# Altium schematic sheet 3 - Sensors, LoRa.SchDoc
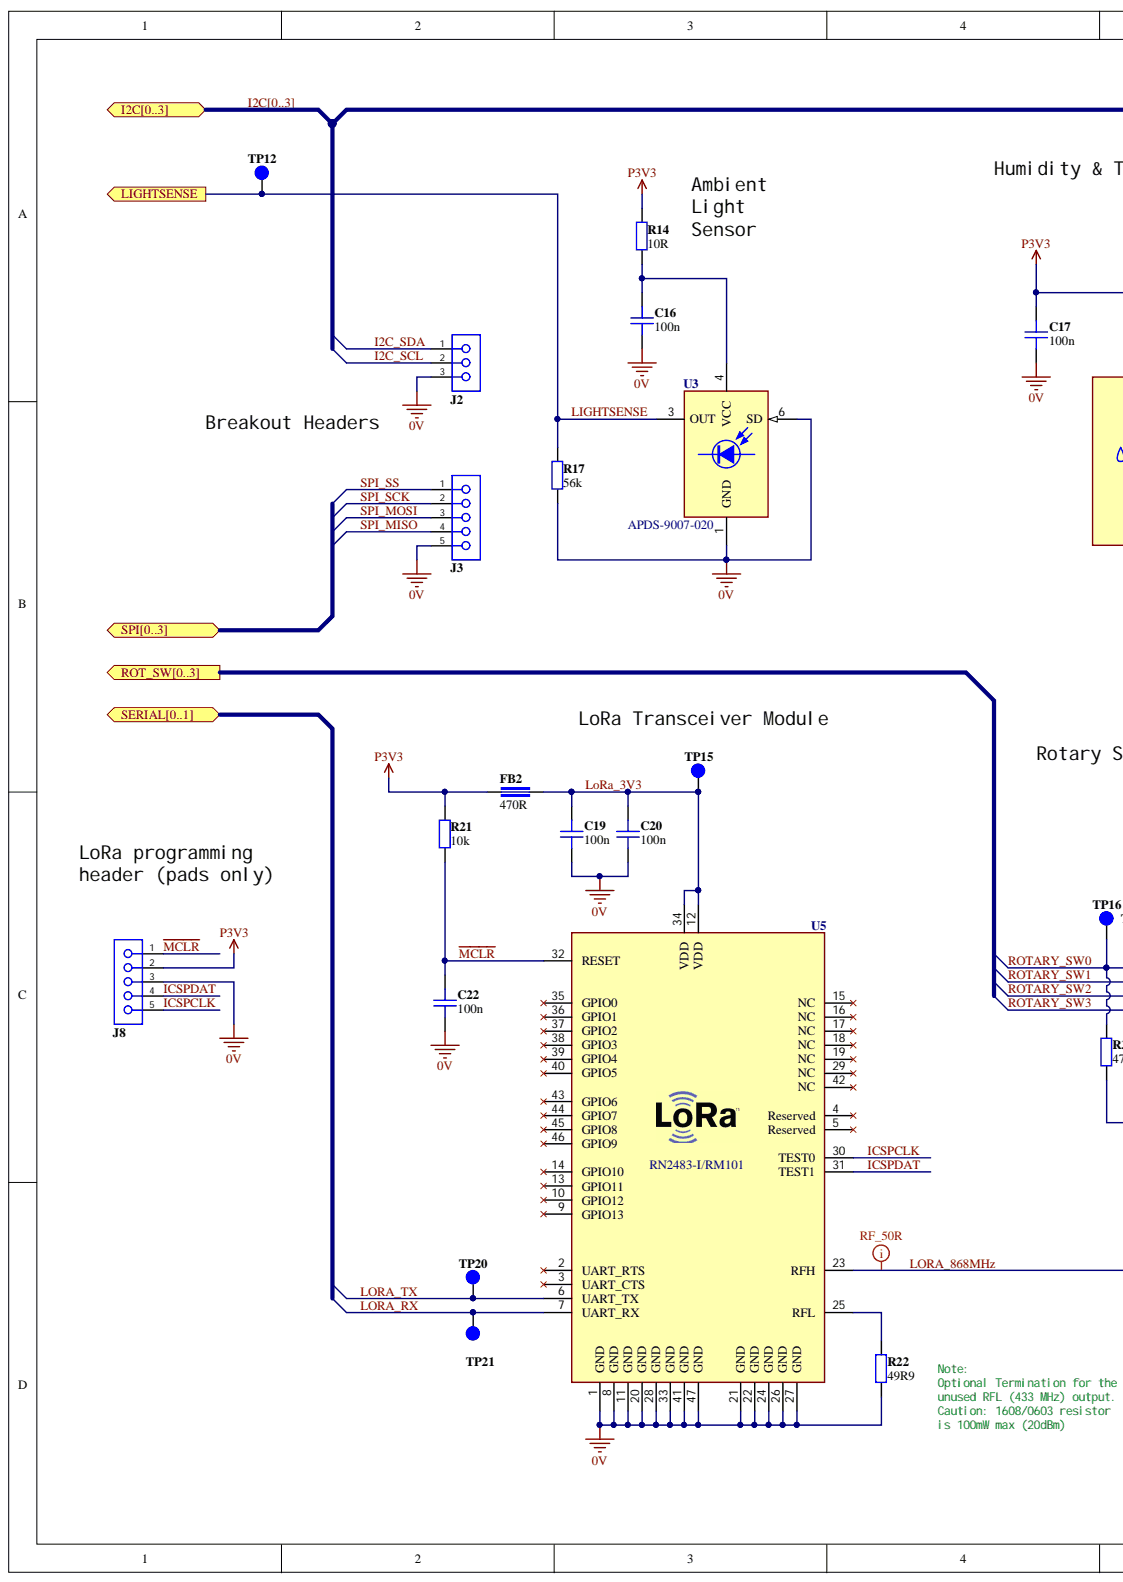
[diagram p0: 3 - Sensors, LoRa.SchDoc, left]
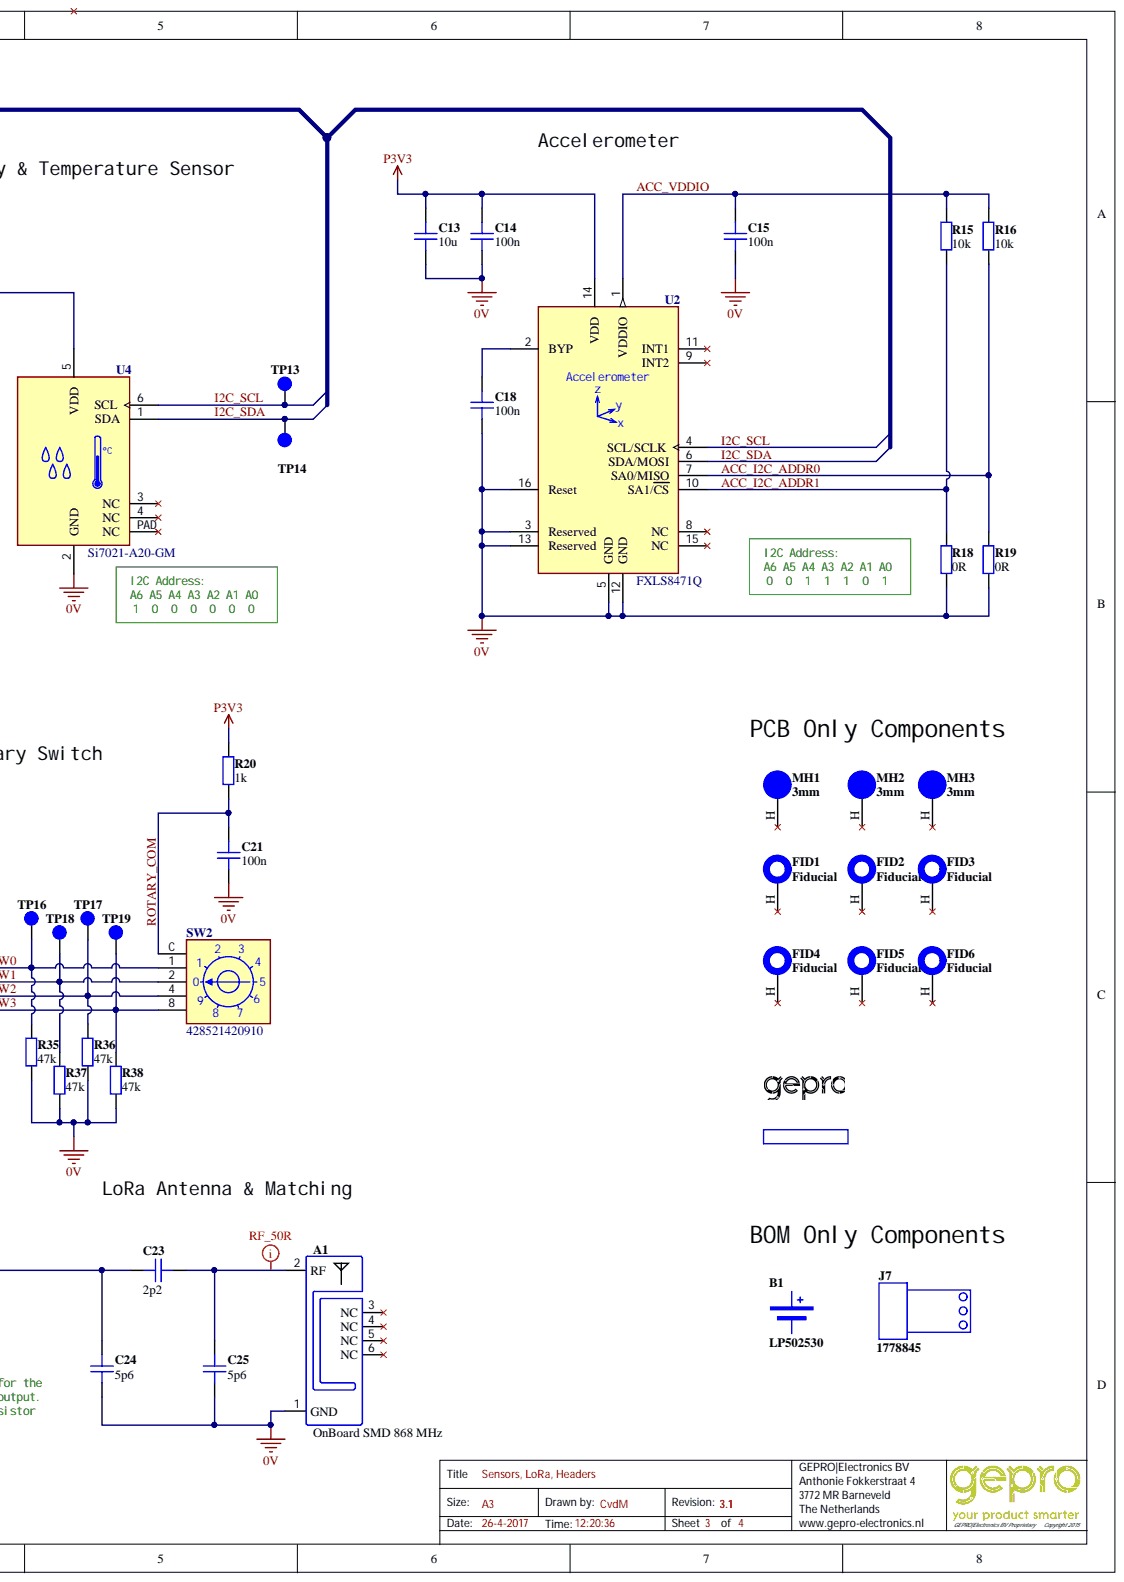
[diagram p1: 3 - Sensors, LoRa.SchDoc, right]

## Parts
A1  OnBoard SMD 868 MHz  Component Type: RF  [ProAnt_Onboard_SMD_868]
B1  LP502530  (mechanical)
C13  10u  [CAPC2012X150N]
C14  100n  [CAPC1608X90N]
C15  100n  [CAPC1608X90N]
C16  100n  [CAPC1608X90N]
C17  100n  [CAPC1608X90N]
C18  100n  [CAPC1608X90N]
C19  100n  [CAPC1608X90N]
C20  100n  [CAPC1608X90N]
C21  100n  [CAPC1608X90N]
C22  100n  [CAPC1608X90N]
C23  2p2  [CAPC1005X55N]
C24  5p6  [CAPC1005X55N]
C25  5p6  [CAPC1005X55N]
FB2  470R  [FB1608X100N]
FID1  Fiducial  [Fiducial]  (no BOM)
FID2  Fiducial  [Fiducial]  (no BOM)
FID3  Fiducial  [Fiducial]  (no BOM)
FID4  Fiducial  [Fiducial]  (no BOM)
FID5  Fiducial  [Fiducial]  (no BOM)
FID6  Fiducial  [Fiducial]  (no BOM)
GR1  Logo Gepro  [LOGO_GEPRO_SLOGAN_20X9MM]  (value not drawn)  (no BOM)
GR2  PCB Name Revision  [PCB_Name_Revision_25x5mm]  (value not drawn)  (no BOM)
J2  Phoenix_1778560  [PHOENIX_1778560]  (value not drawn)
J3  Phoenix_1770982  [PHOENIX_1770982]  (value not drawn)
J7  1778845  (mechanical)
J8  Header 1x5 SMD  [HEADER_1X5_PADSONLY_SMD]  (value not drawn)  (no BOM)
MH1  3mm  [ToolingHole3mm]  (no BOM)
MH2  3mm  [ToolingHole3mm]  (no BOM)
MH3  3mm  [ToolingHole3mm]  (no BOM)
R14  10R  [RESC1608X50N]
R15  10k  [RESC1608X50N]
R16  10k  [RESC1608X50N]
R17  56k  [RESC1608X50N]
R18  0R  [RESC1608X50N]
R19  0R  [RESC1608X50N]
R20  1k  [RESC1608X50N]
R21  10k  [RESC1608X50N]
R22  49R9  [RESC1608X50N]
R35  47k  [RESC1608X50N]
R36  47k  [RESC1608X50N]
R37  47k  [RESC1608X50N]
R38  47k  [RESC1608X50N]
SW2  428521420910  [WurthElektronik_WS-ROSV_428521420910]
TP12  Comment  [Testpoint_PAD_DIA_1mm]  (value not drawn)  (no BOM)
TP13  Comment  [Testpoint_PAD_DIA_1mm]  (value not drawn)  (no BOM)
TP14  Comment  [Testpoint_PAD_DIA_1mm]  (value not drawn)  (no BOM)
TP15  Comment  [Testpoint_PAD_DIA_1mm]  (value not drawn)  (no BOM)
TP16  Comment  [Testpoint_PAD_DIA_1mm]  (value not drawn)  (no BOM)
TP17  Comment  [Testpoint_PAD_DIA_1mm]  (value not drawn)  (no BOM)
TP18  Comment  [Testpoint_PAD_DIA_1mm]  (value not drawn)  (no BOM)
TP19  Comment  [Testpoint_PAD_DIA_1mm]  (value not drawn)  (no BOM)
TP20  Comment  [Testpoint_PAD_DIA_1mm]  (value not drawn)  (no BOM)
TP21  Comment  [Testpoint_PAD_DIA_1mm]  (value not drawn)  (no BOM)
U2  FXLS8471Q  Component Subtype: Accelerometer  [PQFN50P300X300X100-16N_NXP_SOT1676-1_QFN16]
U3  APDS-9007-020  [BROADCOM_APDS-9007-020]
U4  Si7021-A20-GM  [SON95P300X300X80-7T150X240N_SiliconLabs_Si7021-A20]
U5  RN2483-I/RM101  [MICROCHIP_RN2483]

## Ports
I2C0, I2C1, I2C2, I2C3, I2C[0..3], LIGHTSENSE, ROT_SW0, ROT_SW1, ROT_SW2, ROT_SW3, ROT_SW[0..3], SERIAL0, SERIAL1, SERIAL[0..1], SPI0, SPI1, SPI2, SPI3, SPI[0..3]

## Nets
0V: A1.1(GND) C13.2 C14.1 C15.1 C16.2 C17.1 C18.2 C19.2 C20.2 C21.2 C22.2 C24.2 C25.2 J2.3 J3.5 J8.3 R17.2 R18.2 R19.2 R22.2 R35.2 R36.2 R37.2 R38.2 U2.3(Reserved) U2.5(GND) U2.12(GND) U2.13(Reserved) U2.16(Reset) U3.1(GND) U3.6(SD) U4.2(GND) U5.1(GND) U5.8(GND) U5.11(GND) U5.20(GND) U5.21(GND) U5.22(GND) U5.24(GND) U5.26(GND) U5.27(GND) U5.28(GND) U5.33(GND) U5.41(GND) U5.47(GND)
P3V3: C13.1 C14.2 C17.2 FB2.1 J8.2 R14.1 R20.1 R21.1 U2.14(VDD) U4.5(VDD)
ACC_I2C_ADDR0: R16.2 R19.1 U2.7(SA0/MISO)
ACC_I2C_ADDR1: R15.2 R18.1 U2.10(SA1/CS)
ACC_VDDIO: C15.2 R15.1 R16.1 U2.1(VDDIO)
I2C_SCL: J2.2 TP13.1 U2.4(SCL/SCLK) U4.6(SCL)
I2C_SDA: J2.1 TP14.1 U2.6(SDA/MOSI) U4.1(SDA)
ICSPCLK: J8.5 U5.30(TEST0)
ICSPDAT: J8.4 U5.31(TEST1)
LIGHTSENSE: R17.1 TP12.1 U3.3(OUT) ; port LIGHTSENSE
LoRa_3V3: C19.1 C20.1 FB2.2 TP15.1 U5.12(VDD) U5.34(VDD)
LORA_868MHz: C23.1 C24.1 U5.23(RFH)
LORA_RX: TP21.1 U5.7(UART_RX)
LORA_TX: TP20.1 U5.6(UART_TX)
~{MCLR}: C22.1 J8.1 R21.2 U5.32(RESET)
ROTARY_COM: C21.1 R20.2 SW2.C
ROTARY_SW0: R35.1 SW2.1 TP16.1
ROTARY_SW1: R37.1 SW2.2 TP18.1
ROTARY_SW2: R36.1 SW2.4 TP17.1
ROTARY_SW3: R38.1 SW2.8 TP19.1
SPI_MISO: J3.4
SPI_MOSI: J3.3
SPI_SCK: J3.2
SPI_SS: J3.1
NetA1_2: A1.2(RF) C23.2 C25.1
NetC16_1: C16.1 R14.2 U3.4(VCC)
NetC18_1: C18.1 U2.2(BYP)
NetR22_1: R22.1 U5.25(RFL)
I2C0: ; port I2C[0..3]  [also labelled I2C]
I2C1: ; port I2C[0..3]  [also labelled I2C]
I2C2: ; port I2C[0..3]  [also labelled I2C]
I2C3: ; port I2C[0..3]  [also labelled I2C]
ROT_SW[0..3]:
SERIAL[0..1]:
SPI[0..3]:

Not connected: A1 3(NC) 4(NC) 5(NC) 6(NC); FID1 H; FID2 H; FID3 H; FID4 H; FID5 H; FID6 H; MH1 H; MH2 H; MH3 H; U2 8(NC) 9(INT2) 11(INT1) 15(NC); U4 3(NC) 4(NC) PAD(NC); U5 2(UART_RTS) 3(UART_CTS) 4(Reserved) 5(Reserved) 9(GPIO13) 10(GPIO12) 13(GPIO11) 14(GPIO10) 15(NC) 16(NC) 17(NC) 18(NC) 19(NC) 29(NC) 35(GPIO0) 36(GPIO1) 37(GPIO2) 38(GPIO3) 39(GPIO4) 40(GPIO5) 42(NC) 43(GPIO6) 44(GPIO7) 45(GPIO8) 46(GPIO9)

## Library data of the parts
A1 = Onboard_SMD_868_915: Antenna SMD 868/915 MHz; Manufacturer: Proant-AB; Manufacturer Part Number: 471; Component Subtype: RF Antenna; Frequency (Center/Band): 868MHz, 915MHz; Package Technology: SMT; Polarized?: Yes; Component Kind: Standard; ComponentLink1Description: Data Sheet; ComponentLink1URL: http://www.proant.se/files/user/Datasheet%20-%20OnBoard%20SMD%20868-915%20MHz%20rev%202.0.pdf; ComponentLink2Description: Application Note / Data Sheet for 868 MHz; ComponentLink2URL: http://www.proant.se/files/user/Application%20note%20-%20OnBoard%20SMD%20868%20rev%202.0.pdf; ComponentLink3Description: Application Note / Data Sheet for 915 MHz; ComponentLink3URL: http://www.proant.se/files/user/Application%20note%20-%20OnBoard%20SMD%20915%20MHz%20rev%202.0.pdf; Package / Case: Surface Mount; RoHS Compliant?: Yes; Library Name: KISS_IoT.SchLib
B1 = Battery_LP502530: LiPo Battery 3.7V 350mAh; Manufacturer: GeB General Electronics Battery Co., LTD.; Capacity (Minimum): 330mAh; Chemistry: Li-ion Polymer; Maximum  Continuous Charge/Discharge Current: 1.0C; Voltage (Charging): 4.2 V ±0.05 V; Voltage (Discharge Cut-off): 2.8 V; Capacity (Nominal): 350mAh; Manufacturer Part Number: LP502530; Operating Temperature Range (Charge): 0°C ~ 45°C; Operating Temperature Range (Discharge): -20°C ~ 60°C; Voltage (Nominal): 3.7 V; Library Name: KISS_IoT.SchLib
C13 = CAP_MLCC_2012_X7R_10uF_10V: Capacitor MLCC 0805 (2012 Metric) X7R 10V 10µF 10%; Manufacturer: No Preference; Manufacturer Part Number: Select according to description parameters; Package Technology: SMT; Polarized?: No; Capacitance: 10µF; Component Kind: Standard; Component Subtype: MLCC; Component Type: Capacitor; Dielectric Type: X7R; Operating Temperature Range: -55°C ~ 125°C; Package / Case: 0805 (2012 Metric); Pin Count: 2; RoHS Compliant?: Yes; Tolerance: ±10%; Voltage Rating: 10V; Library Name: KISS_IoT.SchLib
C14-C22 = CAP_MLCC_1608_X7R_100nF_50V: Capacitor MLCC 0603 (1608 Metric) X7R 50V 100nF 10%; Manufacturer: No Preference; Manufacturer Part Number: Select according to description parameters; Package Technology: SMT; Polarized?: No; Capacitance: 100nF; Component Kind: Standard; Component Subtype: MLCC; Component Type: Capacitor; Dielectric Type: X7R; Operating Temperature Range: -55°C ~ 125°C; Package / Case: 0603 (1608 Metric); Pin Count: 2; RoHS Compliant?: Yes; Tolerance: ±10%; Voltage Rating: 50V; Library Name: KISS_IoT.SchLib
C23 = GRM1555C1H2R2CA01D: Capacitor MLCC 0402 (1005 Metric) C0G (NP0) 16V 2.2pF ±0.5pF; Manufacturer: Murata Electronics North America; Package Technology: SMT; Polarized?: No; Voltage Rating: 50V; Capacitance: 2.2pF; Component Kind: Standard; Component Subtype: MLCC; Component Type: Capacitor; Dielectric Type: C0G (NP0); Manufacturer Part Number: GRM1555C1H2R2CA01D; Operating Temperature Range: -55°C ~ 125°C; Package / Case: 0402 (1005 Metric); Pin Count: 2; RoHS Compliant?: Yes; Tolerance: ±0.5pF; Library Name: KISS_IoT.SchLib
C24 C25 = GRM1555C1H5R6CA01D: Capacitor MLCC 0402 (1005 Metric) C0G (NP0) 16V 5.6pF ±0.5pF; Manufacturer: Murata Electronics North America; Package Technology: SMT; Polarized?: No; Voltage Rating: 50V; Capacitance: 5.6pF; Component Kind: Standard; Component Subtype: MLCC; Component Type: Capacitor; Dielectric Type: C0G (NP0); Manufacturer Part Number: GRM1555C1H5R6CA01D; Operating Temperature Range: -55°C ~ 125°C; Package / Case: 0402 (1005 Metric); Pin Count: 2; RoHS Compliant?: Yes; Tolerance: ±0.5pF; Library Name: KISS_IoT.SchLib
FB2 = 742792645: Chip Ferrite Bead 0603 (1608 Metric) 470R @ 100MHz 1A; Manufacturer: Würth Elektronik; DC Resistance (DCR): 200 mOhm; Impedance @ Frequency: 470 Ohm @ 100MHz; Package Technology: SMT; Polarized?: No; Component Kind: Standard; ComponentLink1Description: Data Sheet; ComponentLink1URL: http://katalog.we-online.com/pbs/datasheet/742792645.pdf; Component Subtype: Chip Ferrite Bead; Component Type: Filter; Current Rating: 1A; Manufacturer Part Number: 742792645; Operating Temperature Range: -55°C ~ 125°C; Package / Case: 0603 (1608 Metric); Pin Count: 2; RoHS Compliant?: Yes; Library Name: KISS_IoT.SchLib
J2 = Phoenix_1778560: CONN HEADER 3POS 2.5MM; Manufacturer: Phoenix Contact; Type (manufacturer type): PTSM 0.5/ 3-HV-2.5-THR R32; Nominal current: 6A; Nominal voltage: 50V; Specification: Ground casing, vertical; Colour: Black; Component Kind: Standard; ComponentLink1Description: https://datasheet.ciiva.com/796/1778560-796650.pdf; ComponentLink1URL: https://datasheet.ciiva.com/796/1778560-796650.pdf; ComponentLink2Description: https://datasheet.ciiva.com/29211/734134-da-01-de-grundgehaeuse-ptsm-0-5-3-hv-2-5-thr-r32-29211883.pdf; ComponentLink2URL: https://datasheet.ciiva.com/29211/734134-da-01-de-grundgehaeuse-ptsm-0-5-3-hv-2-5-thr-r32-29211883.pdf; ComponentLink3Description: https://datasheet.ciiva.com/16939/70170402-16939781.pdf; ComponentLink3URL: https://datasheet.ciiva.com/16939/70170402-16939781.pdf; ComponentLink4Description: https://datasheet.ciiva.com/796/1778560-796651.pdf; ComponentLink4URL: https://datasheet.ciiva.com/796/1778560-796651.pdf; Contact material: Carbonite; Grid pitch: 2.5mm; Manufacturer Part Number: 1778560; Number of pins: 3; Pricing 1: 1=0.71, 10=0.69, 50=0.66, 100=0.642, 1000=0.51, 10000=0.51 (USD); Stock 1: 756; Supplier 1: Mouser; Supplier Part Number 1: 651-1778560; Library Name: KISS_IoT.SchLib
J3 = Phoenix_1770982: CONN TERM BLK 5POS 2.5MM; Part # Aliases: PTSM_0,5/_5-2,5-V_THR_R44 PTSM05525VTHRR44; Brand: Phoenix Contact; Current Rating: 6 A; Mounting Angle: Vertical; Number of Positions: 5; Voltage Rating: 200 V; Wire Gauge Max: 20; Wire Gauge Min: 24; Wire Gauge Range: 24-20; Color: Black; Component Kind: Standard; ComponentLink1Description: https://datasheet.ciiva.com/824/1770982-824257.pdf; ComponentLink1URL: https://datasheet.ciiva.com/824/1770982-824257.pdf; ComponentLink2Description: https://datasheet.ciiva.com/824/1770982-824258.pdf; ComponentLink2URL: https://datasheet.ciiva.com/824/1770982-824258.pdf; Factory Pack Quantity: 310; Flammability Rating: UL 94 V-0; Length: 5 mm; Manufacturer: Phoenix Contact; Manufacturer Part Number: 1770982; Mounting Style: Through Hole; Number of Positions / Contacts: 5; Packaging: Reel; Pitch: 2.5 mm; Pricing 1: 1=1.12, 10=1.04, 50=1, 100=0.957, 1000=0.74, 10000=0.721 (USD); Product: Fixed Terminal Blocks; Product Category: Fixed Terminal Blocks; RoHS: Details; Series: PTSM 0,5; Stock 1: 971; Supplier 1: Mouser; Supplier Part Number 1: 651-1770982; Termination Style: Through Hole; Tradename: COMBICON Compact; Type: Wire to Board; Library Name: KISS_IoT.SchLib
J7 = Phoenix_1778845: 3 Position Terminal Block Plug; Manufacturer: Phoenix Contact; Manufacturer Part Number: 1778845; Library Name: KISS_IoT.SchLib
J8 = HDR1X5_PadsOnly_SMD: Description: Header 1x5 SMD; Library Name: KISS_IoT.SchLib
MH1-MH3 = ToolingHole: Tooling Hole; Library Name: KISS_IoT.SchLib
R14 = (no symbol name): Resistor 0603 (1608 Metric) Thick Film 100mW 10R 1%; Manufacturer: No Preference; Manufacturer Part Number: Select according to description parameters; Component Subtype: Chip SMT; Package Technology: SMT; Polarized Footprint?: No; Resistance: 10Ω; Temperature Coefficient: ±100 ppm/K; Voltage Rating: 75V; Component Kind: Standard; Component Type: Resistor; Operating Temperature Range: -55°C ~ 155°C; Package / Case: 0603 (1608 Metric); Pin Count: 2; Power Rating: 100mW; Resistor Type: Thick Film; RoHS Compliant?: Yes; Tolerance: 1%; Library Name: KISS_IoT.SchLib
R15 R16 R21 = (no symbol name): Resistor 0603 (1608 Metric) Thick Film 100mW 10k 1%; Manufacturer: No Preference; Manufacturer Part Number: Select according to description parameters; Component Subtype: Chip SMT; Package Technology: SMT; Polarized Footprint?: No; Resistance: 10kΩ; Temperature Coefficient: ±100 ppm/K; Unique ID: GCD_0001470; Voltage Rating: 75V; Component Kind: Standard; Component Type: Resistor; Operating Temperature Range: -55°C ~ 155°C; Package / Case: 0603 (1608 Metric); Pin Count: 2; Power Rating: 100mW; Resistor Type: Thick Film; RoHS Compliant?: Yes; Tolerance: 1%; Library Name: KISS_IoT.SchLib
R17 = (no symbol name): Resistor 0603 (1608 Metric) Thick Film 100mW 56k 1%; Manufacturer: No Preference; Manufacturer Part Number: Select according to description parameters; Component Subtype: Chip SMT; Package Technology: SMT; Polarized Footprint?: No; Resistance: 56kΩ; Temperature Coefficient: ±100 ppm/K; Voltage Rating: 75V; Component Kind: Standard; Component Type: Resistor; Operating Temperature Range: -55°C ~ 155°C; Package / Case: 0603 (1608 Metric); Pin Count: 2; Power Rating: 100mW; Resistor Type: Thick Film; RoHS Compliant?: Yes; Tolerance: 1%; Library Name: KISS_IoT.SchLib
R18 R19 = (no symbol name): Resistor 0603 (1608 Metric) Thick Film 2.0A 0R 1%; Manufacturer: No Preference; Manufacturer Part Number: Select according to description parameters; Component Subtype: Chip SMT; Package Technology: SMT; Polarized Footprint?: No; Resistance: 0Ω; Temperature Coefficient: ±100 ppm/K; Voltage Rating: 75V; Component Kind: Standard; Component Type: Resistor; Operating Temperature Range: -55°C ~ 155°C; Package / Case: 0603 (1608 Metric); Pin Count: 2; Power Rating: 2.0A; Resistor Type: Thick Film; RoHS Compliant?: Yes; Tolerance: 1%; Library Name: KISS_IoT.SchLib
R20 = (no symbol name): Resistor 0603 (1608 Metric) Thick Film 100mW 1k 1%; Manufacturer: No Preference; Manufacturer Part Number: Select according to description parameters; Component Subtype: Chip SMT; Package Technology: SMT; Polarized Footprint?: No; Resistance: 1kΩ; Temperature Coefficient: ±100 ppm/K; Voltage Rating: 75V; Component Kind: Standard; Component Type: Resistor; Operating Temperature Range: -55°C ~ 155°C; Package / Case: 0603 (1608 Metric); Pin Count: 2; Power Rating: 100mW; Resistor Type: Thick Film; RoHS Compliant?: Yes; Tolerance: 1%; Library Name: KISS_IoT.SchLib
R22 = (no symbol name): Resistor 0603 (1608 Metric) Thick Film 100mW 49.9R 1%; Manufacturer: No Preference; Manufacturer Part Number: Select according to description parameters; Component Subtype: Chip SMT; Package Technology: SMT; Polarized Footprint?: No; Resistance: 49.9Ω; Temperature Coefficient: ±100 ppm/K; Voltage Rating: 75V; Component Kind: Standard; Component Type: Resistor; Operating Temperature Range: -55°C ~ 155°C; Package / Case: 0603 (1608 Metric); Pin Count: 2; Power Rating: 100mW; Resistor Type: Thick Film; RoHS Compliant?: Yes; Tolerance: 1%; Library Name: KISS_IoT.SchLib
R35-R38 = (no symbol name): Resistor 0603 (1608 Metric) Thick Film 100mW 47k 1%; Manufacturer: No Preference; Manufacturer Part Number: Select according to description parameters; Component Subtype: Chip SMT; Package Technology: SMT; Polarized Footprint?: No; Resistance: 47kΩ; Temperature Coefficient: ±100 ppm/K; Voltage Rating: 75V; Component Kind: Standard; Component Type: Resistor; Operating Temperature Range: -55°C ~ 155°C; Package / Case: 0603 (1608 Metric); Pin Count: 2; Power Rating: 100mW; Resistor Type: Thick Film; RoHS Compliant?: Yes; Tolerance: 1%; Library Name: KISS_IoT.SchLib
SW2 = 428521420910: Switch Rotary SMT 12x12mm 24V 150mA 80mR 10Pos; Manufacturer: Würth Elektronik; Circuit: BCD; Number of Positions: 10; Polarized?: Yes; Voltage Rating: 24VDC; Component Kind: Standard; ComponentLink1Description: Data Sheet; ComponentLink1URL: http://katalog.we-online.de/em/datasheet/42852142091x_10_Pos.pdf; Component Subtype: Rotary; Component Type: Switch; Current Rating: 150mA; Manufacturer Part Number: 428521420910; Operating Temperature Range: -40°C ~ +85°C; Package / Case: SMT Gull Wing; Package Technology: SMT; Pin Count: 6; RoHS Compliant?: Yes; Library Name: KISS_IoT.SchLib
TP12-TP21 = TestPoint_SMD_Pad: Test Point round pad 1mm, 2mm ring; Component Subtype: Copper Pad Round; Component Type: Test Point; Library Name: KISS_IoT.SchLib
U2 = FXLS8471Q: Sensor Accelerometer I2C/SPI; Manufacturer: NXP (Freescale); Manufacturer Part Number: FXLS8471QR1; Acceleration Range: ±2g, 4g, 8g; Bandwidth: 0.78Hz ~ 400Hz; Output Type: Digital, I²C / SPI; Package Technology: SMT; Polarized?: Yes; Sensitivity (LSB/g): 4096 (±2g) ~ 1024 (±8g); Supply Voltage: 1.95 V ~ 3.6 V; Component Kind: Standard; ComponentLink1Description: Data Sheet; ComponentLink1URL: http://cache.freescale.com/files/sensors/doc/data_sheet/FXLS8471Q.pdf; Component Type: Sensor/Transducer; Operating Temperature Range: -40°C ~ 85°C (TA); Package / Case: 16-VFQFN; Pin Count: 16; RoHS Compliant?: Yes; Library Name: KISS_IoT.SchLib
U3 = APDS-9007-020: Sensor Ambient Light Analog Current Output; Manufacturer: Broadcom; Component Subtype: Optical Sensor; Output Type: Analog, Current; Package Technology: SMT; Polarized?: Yes; Component Kind: Standard; ComponentLink1Description: Data Sheet; ComponentLink1URL: http://www.avagotech.com/docs/AV02-0512EN; Component Type: Sensor/Transducer; Manufacturer Part Number: APDS-9007-020; Operating Temperature Range: -40°C ~ 85°C; Package / Case: 6-SMD, No Lead; Pin Count: 4; RoHS Compliant?: Yes; Library Name: KISS_IoT.SchLib
U4 = Si7021-A20-GM: Sensor Humidity and Temperature I2C 12bits; Manufacturer: Silicon Labs; Component Subtype: Humidity & Temperature Sensor; Output Type: I²C; Package Technology: SMT; Polarized?: Yes; Supply Voltage: 1.9 V ~ 3.6 V; Component Kind: Standard; ComponentLink1Description: Data Sheet; ComponentLink1URL: https://www.silabs.com/Support%20Documents%2fTechnicalDocs%2fSi7021-A20.pdf; Component Type: Sensor/Transducer; Manufacturer Part Number: Si7021-A20-GM; Operating Temperature Range: –40 to +85 °C; Package / Case: 6-WSON/DFN; Pin Count: 6; RoHS Compliant?: Yes; Library Name: KISS_IoT.SchLib
U5 = RN2483-I/RM101: RF Transceiver Module LoRa/FSK/OOK; Manufacturer: Microchip Technology; Component Subtype: RF Transciever Module; Frequency: 433MHz, 868MHz; Interfaces: UART; Package Technology: SMT; Polarized?: Yes; Protocol: LoRa; Supply Voltage: 2.1 V ~ 3.6 V; Component Kind: Standard; ComponentLink1Description: Data Sheet; ComponentLink1URL: http://ww1.microchip.com/downloads/en/DeviceDoc/50002346B.pdf; Component Type: RF; Manufacturer Part Number: RN2483-I/RM101; Operating Temperature Range: -40°C ~ 85°C; Package / Case: Surface Mount Module; Pin Count: 43; RoHS Compliant?: Yes; Library Name: KISS_IoT.SchLib
FID1-FID6 = Fiducial: Description: Fiducial; Library Name: KISS_IoT.SchLib
GR1 = Logo Gepro: Description: Logo Gepro; Library Name: GeproLib_NoBom.SchLib
GR2 = PCB Name Revision: Description: PCB Name Revision; Library Name: GeproLib_NoBom.SchLib
